# Altium schematic sheet 05_GAP_MIC.SchDoc(05_GAP_MIC)
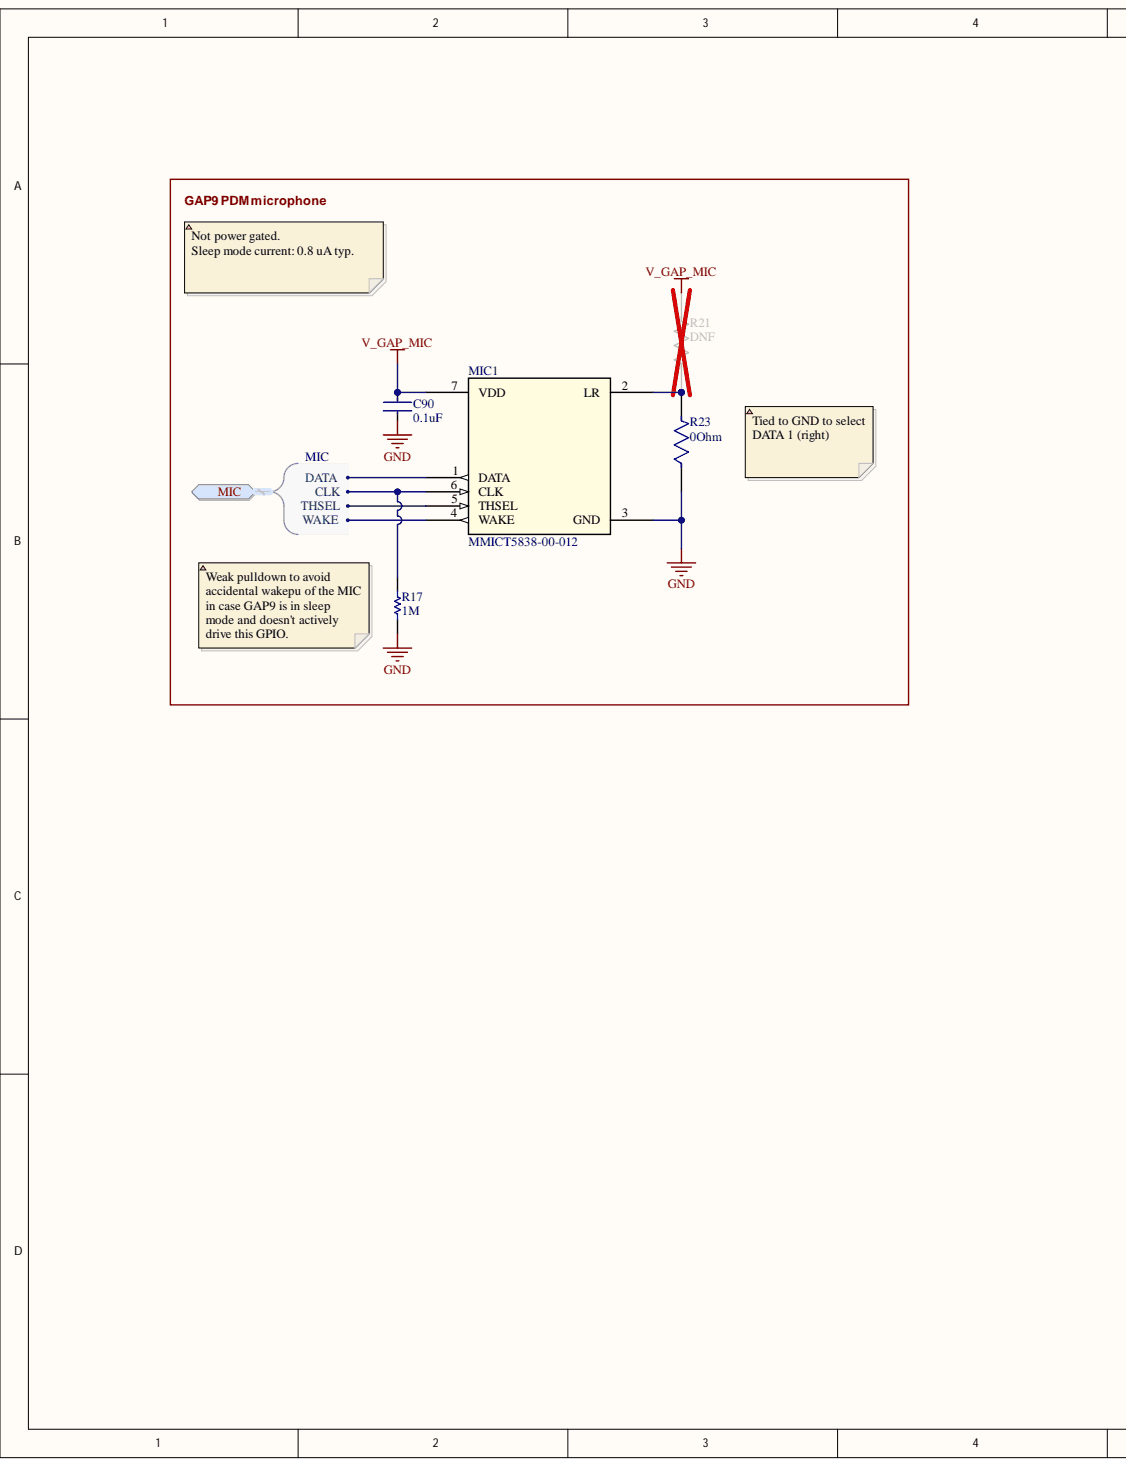
[diagram p0: 05_GAP_MIC.SchDoc, left]
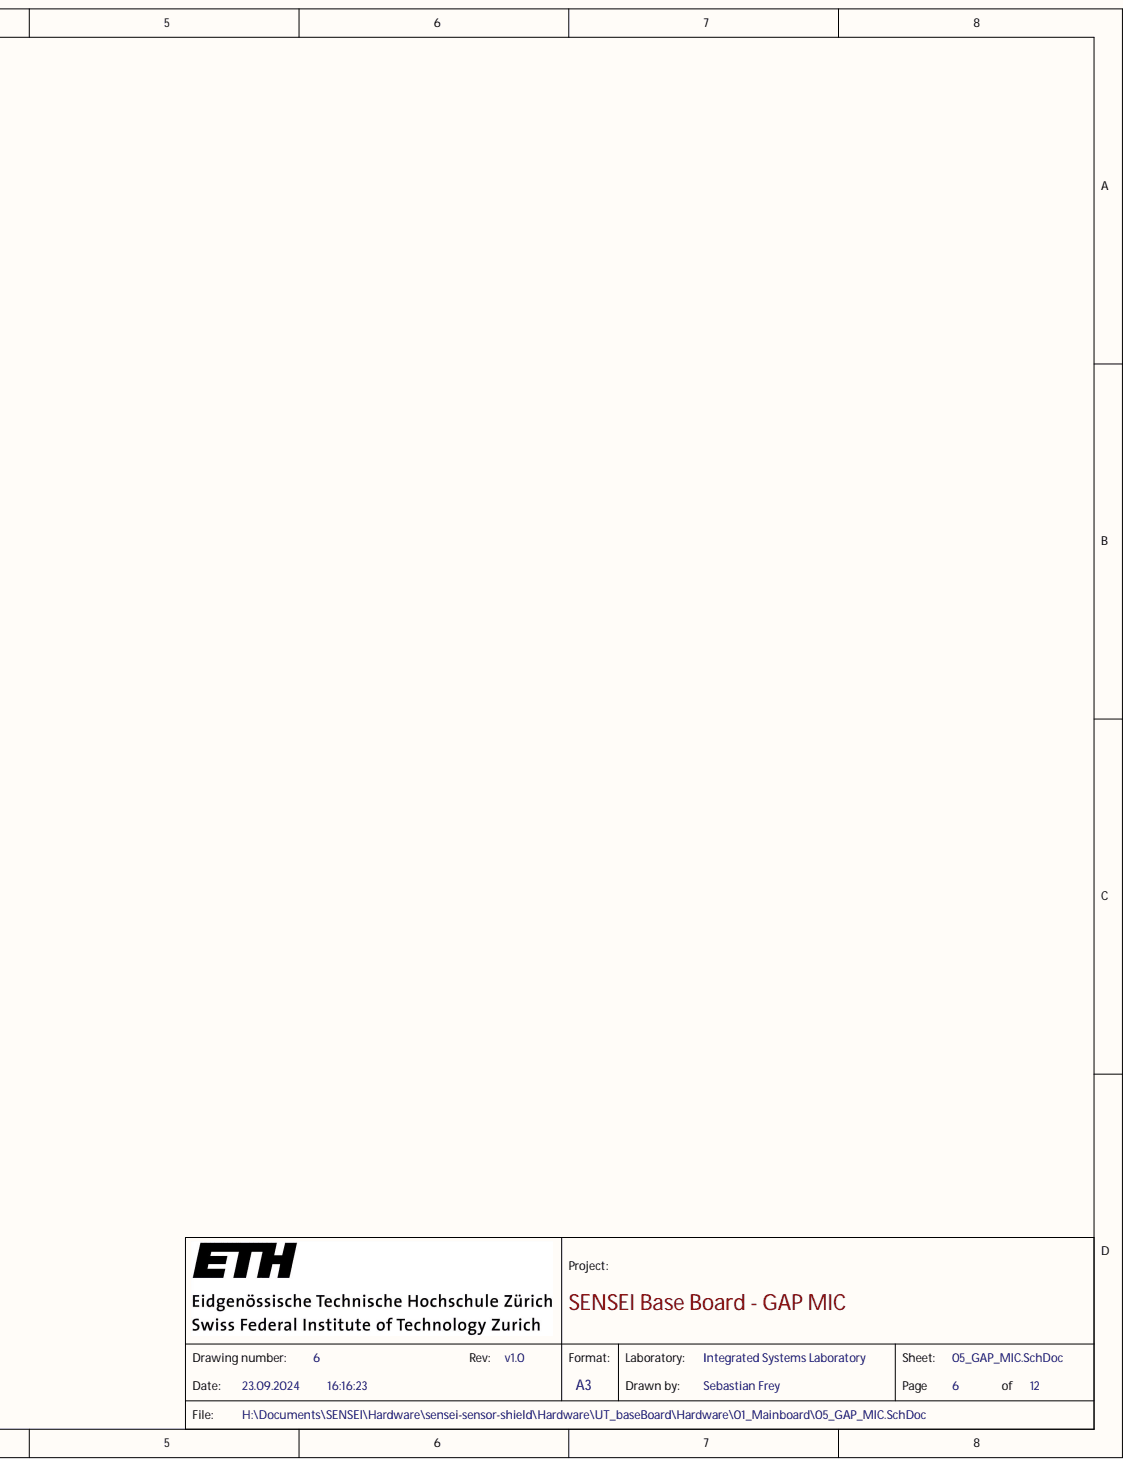
[diagram p1: 05_GAP_MIC.SchDoc, right]

## Parts
C90  Capacitance: 0.1uF  [FP-GRM033-0_03-IPC_B]  (hidden comment: GRM033Z71C104KE14D)
MIC1  MMICT5838-00-012  [MMICT5838-00-012]
R17  value 1M  [RESC0201(0603)_L]  (hidden comment: ERJ-1GNJ105C)
R21  DNF  [FP-RMCF0201-IPC_C]
R23  Resistance: 0Ohm  [FP-RMCF0201-IPC_C]  (hidden comment: RMCF0201ZT0R00)

## Ports
MIC, MIC.CLK, MIC.DATA, MIC.THSEL, MIC.WAKE

## Nets
GND: C90.2 MIC1.3(GND) R17.1 R23.1
V_GAP_MIC: C90.1 MIC1.7(VDD) R21.2
NetMIC1_1: MIC1.1(DATA) ; port MIC
NetMIC1_2: MIC1.2(LR) R21.1 R23.2
NetMIC1_4: MIC1.4(WAKE) ; port MIC
NetMIC1_5: MIC1.5(THSEL) ; port MIC
NetMIC1_6: MIC1.6(CLK) R17.2 ; port MIC

## Library data of the parts
C90 = CMP-06035-035508-1: Chip Multilayer Ceramic Capacitors for General Purpose, 0201, 0.10uF, X7R, 15%, 10%, 16V; Case/Package: 0201; Component Kind: Standard; Library Name: Altium Content Vault
MIC1 = MMICT5838-00-012: No Description Available; Mfr_Name: InvenSense; Component Kind: Standard; Library Name: EEG_SchLib.SchLib; Manufacturer_Part_Number: MMICT5838-00-012; RefDes: RefDes; Type: DEV; Copyright: Copyright (C) 2023 Ultra Librarian. All rights reserved.
R17 = CMP-1010-00146-1: 1M 0.05W 5% 0201 (0603 Metric)  SMD; Case-EIA: 0201; Case-Metric: 0603; Component Kind: Standard; Library Name: Altium Content Vault; Power: 0.05 W; Technology: SMT; Tolerance: 5 %
R21 = CMP-26527-001890-1: Component Kind: Standard; Library Name: Altium Content Vault
R23 = CMP-26527-001890-1: 0Ω ±0 0.05W 0201 Thick Film Chip Resistor AEC-Q200 compliant; Max Power Dissipation: 50mW; Case Code (Imperial): 0201; Case Code (Metric): 0603; Case/Package: 0201; Component Kind: Standard; Contact Plating: Tin; Height: 0.009inch; Height - Seated (Max): 0.01inch; Lead Free: Lead Free; Length: 0.024inch; Library Name: Altium Content Vault; Max Operating Temperature: 125°C; Min Operating Temperature: -55°C; Mount: Surface Mount; Number of Terminations: 2; Package Quantity: 10000; Packaging: Tape and Reel; Power Rating: 50mW; Radiation Hardening: No; Resistor Type: Thick Film; RoHS Compliant: Yes; Series: RMCF; Width: 0.012inch
